# Altium schematic sheet Button.SchDoc(Button)
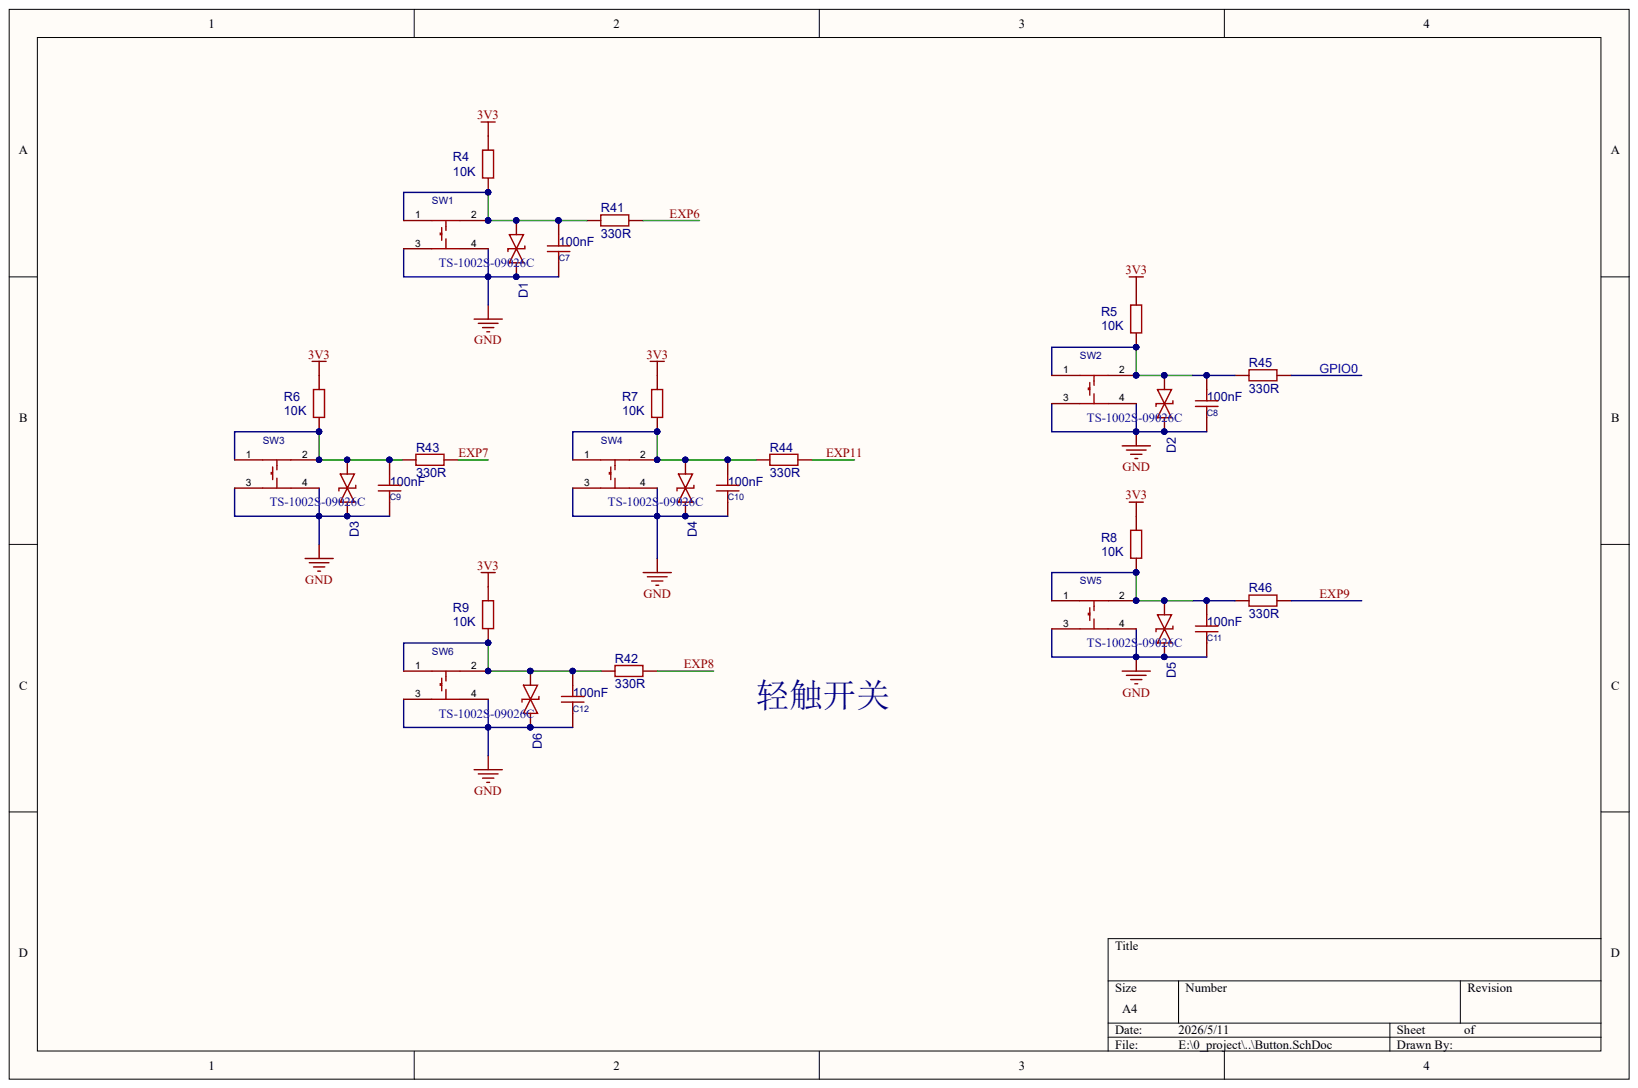
[diagram p0: Button.SchDoc]

## Parts
C7  100nF
C8  100nF
C9  100nF
C10  100nF
C11  100nF
C12  100nF
D1  LESD8D3.3CAT5G  (value not drawn)
D2  LESD8D3.3CAT5G  (value not drawn)
D3  LESD8D3.3CAT5G  (value not drawn)
D4  LESD8D3.3CAT5G  (value not drawn)
D5  LESD8D3.3CAT5G  (value not drawn)
D6  LESD8D3.3CAT5G  (value not drawn)
R4  10K
R5  10K
R6  10K
R7  10K
R8  10K
R9  10K
R41  value 330R  (hidden comment: 10K)
R42  value 330R  (hidden comment: 10K)
R43  value 330R  (hidden comment: 10K)
R44  value 330R  (hidden comment: 10K)
R45  value 330R  (hidden comment: 10K)
R46  value 330R  (hidden comment: 10K)
SW1  TS-1002S-09026C
SW2  TS-1002S-09026C
SW3  TS-1002S-09026C
SW4  TS-1002S-09026C
SW5  TS-1002S-09026C
SW6  TS-1002S-09026C

## Nets
3V3: R4.2 R5.2 R6.2 R7.2 R8.2 R9.2
GND: C7.1 C8.1 C9.1 C10.1 C11.1 C12.1 D1.1 D2.1 D3.1 D4.1 D5.1 D6.1 SW1.3 SW1.4 SW2.3 SW2.4 SW3.3 SW3.4 SW4.3 SW4.4 SW5.3 SW5.4 SW6.3 SW6.4
EXP6: R41.1
EXP7: R43.1
EXP8: R42.1
EXP9: R46.1
EXP11: R44.1
GPIO0: R45.1
NetC7_2: C7.2 D1.2 R4.1 R41.2 SW1.1 SW1.2
NetC8_2: C8.2 D2.2 R5.1 R45.2 SW2.1 SW2.2
NetC9_2: C9.2 D3.2 R6.1 R43.2 SW3.1 SW3.2
NetC10_2: C10.2 D4.2 R7.1 R44.2 SW4.1 SW4.2
NetC11_2: C11.2 D5.2 R8.1 R46.2 SW5.1 SW5.2
NetC12_2: C12.2 D6.2 R9.1 R42.2 SW6.1 SW6.2

## Library data of the parts
C7-C12 = (no symbol name): Symbol: GRM155R71H104KE14D; AddInBom: yes; ModelId: 36a51122f27c49738f86b3bfa98863e0; ModelName: C0402_L1.0-W0.5-H0.5; ModelTransform: 39.37,19.685,0,0,0,0,0,0,0; Voltage Rated: 50V; Temperature Coefficient: X7R; Value: 100nF; Device: GRM155R71H104KE14D; Supplier: LCSC; Manufacturer Part: GRM155R71H104KE14D; Capacitance: 100nF; Supplier Part: C77020; Supplier Footprint: 0402; Datasheet: https://atta.szlcsc.com/upload/public/pdf/source/20180326/C77020_39BD49C951FF1AF0DB1F1FAC5AC1E401.pdf; ConvertToPcb: yes; FootprintName: R0402
D1-D6 = (no symbol name): Device: LESD8D3.3CAT5G_C172409; AddInBom: yes; ModelId: 072dcf228994456dbe83693440270b5f; ModelName: WBFBP-03E_L1.0-W0.6-P0.64-BL; ModelTransform: 40.1574,23.622,0,0,0,0,0,0,0; Reverse Stand-Off Voltage (Vrwm): 3.3V; Maximum Clamping Voltage: 10V; Peak Pulse Current (Ipp)@10/1000us: 6A; Breakdown Voltage: 5V; Type: ESD; Symbol: LESD8D3.3CAT5G; SupplierPart: C172409; ManufacturerPart: LESD8D3.3CAT5G; Supplier Footprint: SOD-882; Datasheet: https://atta.szlcsc.com/upload/public/pdf/source/20180130/C172409_15172974211921323438.pdf; Supplier: LCSC; ConvertToPcb: yes; FootprintName: SOD-882_L1.0-W0.6-BI
R4-R9 R41-R46 = (no symbol name): Device: 0402WGJ0472TCE; AddInBom: yes; ModelId: 671aefced39d4c318694bba8bd8efd39; ModelName: R0402_L1.0-W0.5-H0.5; ModelTransform: 39.37,19.685,0,0,0,0,0,0.0005,0; Power(Watts): 62.5mW; Overload Voltage (Max): 50V; Symbol: 0402WGJ0472TCE; SupplierPart: C25940; ManufacturerPart: 0402WGJ0472TCE; Supplier Footprint: 0402; Datasheet: https://atta.szlcsc.com/upload/public/pdf/source/20200306/C422600_1E6D84923E4A46A82E41ADD87F860B5C.pdf; Supplier: LCSC; ConvertToPcb: yes; FootprintName: R0402
  R4-R9: Value: 10K
SW1-SW6 = (no symbol name): Symbol: TS-1002S-09026C
